# Altium schematic sheet Schematic.SchDoc
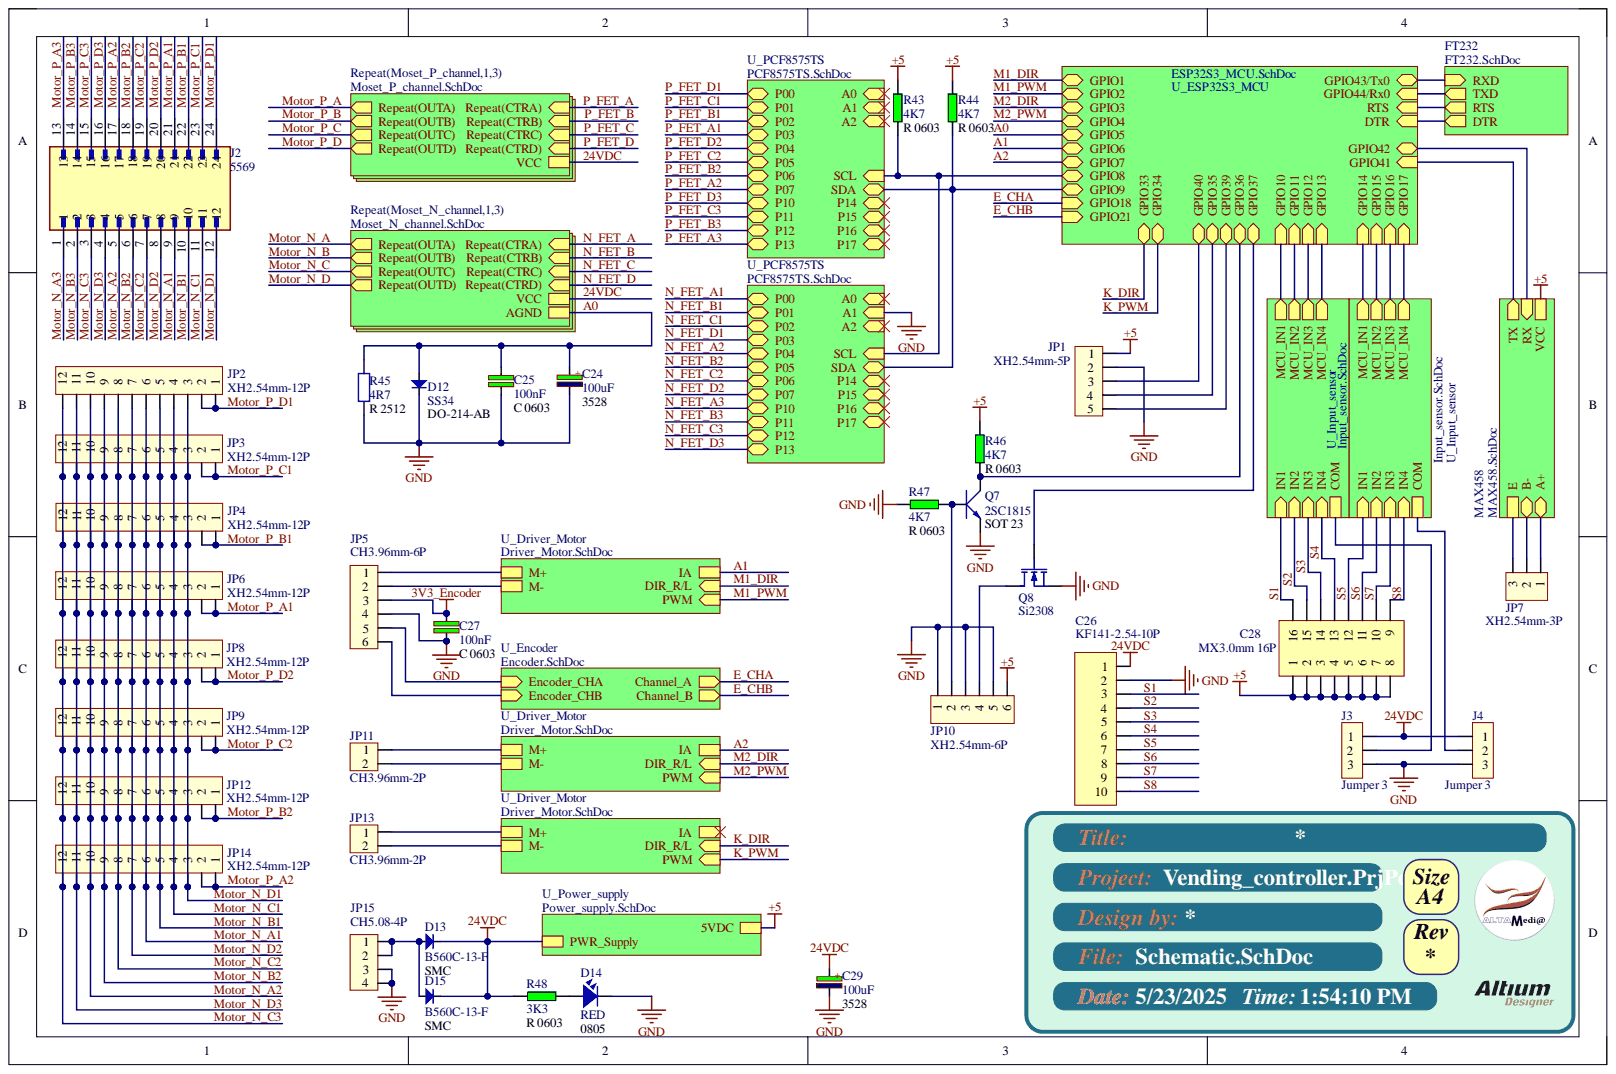
[diagram p0: Schematic.SchDoc]

## Parts
C24  100uF  Size: 3528  [SMD 3528]
C25  100nF  Size: C 0603  [C-0603]
C26  KF141-2.54-10P  [KF141-2.54-10-R]
C27  100nF  Size: C 0603  [C-0603]
C28  MX3.0mm 16P  [MX3.0mm_16P]
C29  100uF  Size: 3528  [CA-ALU-10GR]
D12  SS34  Size: DO-214-AB  [DIO-SMD-106]
D13  B560C-13-F  [DIO-SMD-B]
D14  RED  Size: 0805  [LED-0805-Blue]
D15  B560C-13-F  Size: SMC  [DIO-SMD-B]
J2  5569  [MOLEX_874272442]
J3  Jumper 3  value J3  [JP3]
J4  Jumper 3  [JP3]
JP1  XH2.54mm-5P  [CON_5P]
JP2  XH2.54mm-12P  [CON12]
JP3  XH2.54mm-12P  [CON12]
JP4  XH2.54mm-12P  [CON12]
JP5  CH3.96mm-6P  [CH3.96MM_6P]
JP6  XH2.54mm-12P  [CON12]
JP7  XH2.54mm-3P  [CON3]
JP8  XH2.54mm-12P  [CON12]
JP9  XH2.54mm-12P  [CON12]
JP10  XH2.54mm-6P  [CON_6P]
JP11  CH3.96mm-2P  [CH3.96MM_2P]
JP12  XH2.54mm-12P  [CON12]
JP13  CH3.96mm-2P  [CH3.96MM_2P]
JP14  XH2.54mm-12P  [CON12]
JP15  CH5.08-4P  [CH5.08MM_4P]
Q7  2SC1815  [SOT23B]
Q8  Si2308  [SOT23]
R43  4K7  [R-0603]
R44  4K7  Size: R 0603  [R-0603]
R45  4R7  Size: R 2512  [RES-SMD-2512]
R46  4K7  Size: R 0603  [R-0603]
R47  4K7  [R-0603]
R48  3K3  Size: R 0603  [R-0603]

## Nets
3V3_Encoder: C27.1 JP5.3
+5: C28.1 C28.2 C28.3 C28.4 C28.5 C28.6 C28.7 C28.8 JP1.1 JP10.6 R43.2 R44.2 R46.2
GND: C24.2 C25.2 C26.2 C27.2 C29.2 D12.2 D14.2 J3.3 J4.3 JP1.2 JP5.4 JP10.1 JP10.3 JP10.5 JP15.3 JP15.4 Q7.1 Q8.2 R45.2 R47.1
24VDC: C26.1 C29.1 D13.2 D15.2 J3.1 J4.1 R48.1
A0: C24.1 C25.1 D12.1 R45.1
Motor_N_A1: J2.9 JP2.6 JP3.6 JP4.6 JP6.6 JP8.6 JP9.6 JP12.6 JP14.6
Motor_N_A2: J2.5 JP2.10 JP3.10 JP4.10 JP6.10 JP8.10 JP9.10 JP12.10 JP14.10
Motor_N_A3: J2.1
Motor_N_B1: J2.10 JP2.5 JP3.5 JP4.5 JP6.5 JP8.5 JP9.5 JP12.5 JP14.5
Motor_N_B2: J2.6 JP2.9 JP3.9 JP4.9 JP6.9 JP8.9 JP9.9 JP12.9 JP14.9
Motor_N_B3: J2.2
Motor_N_C1: J2.11 JP2.4 JP3.4 JP4.4 JP6.4 JP8.4 JP9.4 JP12.4 JP14.4
Motor_N_C2: J2.7 JP2.8 JP3.8 JP4.8 JP6.8 JP8.8 JP9.8 JP12.8 JP14.8
Motor_N_C3: J2.3 JP2.12 JP3.12 JP4.12 JP6.12 JP8.12 JP9.12 JP12.12 JP14.12
Motor_N_D1: J2.12 JP2.3 JP3.3 JP4.3 JP6.3 JP8.3 JP9.3 JP12.3 JP14.3
Motor_N_D2: J2.8 JP2.7 JP3.7 JP4.7 JP6.7 JP8.7 JP9.7 JP12.7 JP14.7
Motor_N_D3: J2.4 JP2.11 JP3.11 JP4.11 JP6.11 JP8.11 JP9.11 JP12.11 JP14.11
Motor_P_A1: J2.21 JP6.1 JP6.2
Motor_P_A2: J2.17 JP14.1 JP14.2
Motor_P_A3: J2.13
Motor_P_B1: J2.22 JP4.1 JP4.2
Motor_P_B2: J2.18 JP12.1 JP12.2
Motor_P_B3: J2.14
Motor_P_C1: J2.23 JP3.1 JP3.2
Motor_P_C2: J2.19 JP9.1 JP9.2
Motor_P_C3: J2.15
Motor_P_D1: J2.24 JP2.1 JP2.2
Motor_P_D2: J2.20 JP8.1 JP8.2
Motor_P_D3: J2.16
S1: C26.3 C28.16
S2: C26.4 C28.15
S3: C26.5 C28.14
S4: C26.6 C28.13
S5: C26.7 C28.12
S6: C26.8 C28.11
S7: C26.9 C28.10
S8: C26.10 C28.9
NetD13_1: D13.1 D15.1 JP15.1 JP15.2
NetD14_1: D14.1 R48.2
NetJ3_2: J3.2
NetJ4_2: J4.2
NetJP1_3: JP1.3
NetJP1_4: JP1.4
NetJP1_5: JP1.5
NetJP5_1: JP5.1
NetJP5_2: JP5.2
NetJP5_5: JP5.5
NetJP5_6: JP5.6
NetJP7_1: JP7.1
NetJP7_2: JP7.2
NetJP7_3: JP7.3
NetJP10_2: JP10.2 Q7.3 R47.2
NetJP10_4: JP10.4 Q8.3
NetJP11_1: JP11.1
NetJP11_2: JP11.2
NetJP13_1: JP13.1
NetJP13_2: JP13.2
NetQ7_2: Q7.2 R46.1
NetQ8_1: Q8.1
NetR43_1: R43.1
NetR44_1: R44.1
A1:
A2:
DTR:
E_CHA:
E_CHB:
GPIO10:
GPIO11:
GPIO12:
GPIO13:
GPIO14:
GPIO15:
GPIO16:
GPIO17:
GPIO41:
GPIO42:
GPIO43/Tx0:
GPIO44/Rx0:
IA:
K_DIR:
K_PWM:
M1_DIR:
M1_PWM:
M2_DIR:
M2_PWM:
N_FET_A1:
N_FET_A2:
N_FET_A3:
N_FET_B1:
N_FET_B2:
N_FET_B3:
N_FET_C1:
N_FET_C2:
N_FET_C3:
N_FET_D1:
N_FET_D2:
N_FET_D3:
P14:
P15:
P16:
P17:
P_FET_A1:
P_FET_A2:
P_FET_A3:
P_FET_B1:
P_FET_B2:
P_FET_B3:
P_FET_C1:
P_FET_C2:
P_FET_C3:
P_FET_D1:
P_FET_D2:
P_FET_D3:
RTS:

## Library data of the parts
C24 C29 = CP0: Cap P  0,1uF < 22uF , 16 - 50V; Description 2: 0,1uF => 33uF/50V - 10uF => 22uF/63V; Description 3: 0,47uF => 10uF/100V; Value: 100uF/16V; Component Kind: Standard; Library Name: My Library.SchLib
C25 C27 = CN5: 0,1uF/300V; Component Kind: Standard; Library Name: My Library.SchLib; Value: 100nF
C26 = JP10: WR-PHD 2.54 mm Angled Socket Header; Manufacturer: W¨¹rth Elektronik eiSos GmbH; partNo: 613010143121; ComponentLink1 Description: Manufacturer; ComponentLink2 Description: Datasheet; NoPins: 10; Component Kind: Standard; ComponentLink1URL: http://www.we-online.com; ComponentLink2URL: http://katalog.we-online.de/em/datasheet/6130xx143121.pdf; Created Date: 29 March 2013; Library Name: My Library.SchLib; Created by: Karrer Zheng
C28 = JP8x2: WR-PHD 2.54 mm Dual Socket Header; Manufacturer: W¨¹rth Elektronik eiSos GmbH; partNo: 61301621821; ComponentLink1 Description: Manufacturer; ComponentLink2 Description: Datasheet; NoPins: 16; Component Kind: Standard; ComponentLink1URL: http://www.we-online.com; ComponentLink2URL: http://katalog.we-online.de/em/datasheet/6130xx21821.pdf; Created Date: 29 March 2013; Library Name: My Library.SchLib; Created by: Karrer Zheng
D12 D13 D15 = Diode SMD: Default Diode; Component Kind: Standard; Library Name: My Library.SchLib; Published: 8-Jun-2000; Revision: July-2002: Re-released for DXP Platform.; Publisher: Altium Limited
  D12: Value: SS34
  D13: Size: SMC; Value: B560C-13-F
  D15: Value: B560C-13-F
D14 = LED: LED Single colour Green and Red; FNC: Tx; Component Kind: Standard; Library Name: My Library.SchLib; Value: RED
J2 = 874272442: Availability: Unavailable; Component Kind: Standard; Library Name: My Library.SchLib; MP: 874272442; Package: None; Price: None
J3 = JP3: Component Kind: Standard; Description: Jumper 3; Library Name: My Library.SchLib
J4 = JP3: Value: J3; Component Kind: Standard; Description: Jumper 3; Library Name: My Library.SchLib
JP1 = Con5: Connector 5; Component Kind: Standard; Library Name: My Library.SchLib; Value: Connector 5
JP2-JP4 JP6 JP8 JP9 JP12 JP14 = Con12: Connector 12; Component Kind: Standard; Library Name: My Library.SchLib; Value: Connector 12
JP5 JP10 = Con6: Connector 6; Component Kind: Standard; Library Name: My Library.SchLib; Value: Connector 6
JP7 = Con3: Connector 3; Component Kind: Standard; Library Name: My Library.SchLib; Value: Connector 3
JP11 JP13 = Con2: Connector 2; Component Kind: Standard; Library Name: My Library.SchLib; Value: Connector 2
JP15 = Con4: Connector 4; Component Kind: Standard; Library Name: My Library.SchLib; Value: Connector 4
Q7 = C1815: NPN 600mW, ECB; Component Kind: Standard; Size: SOT 23; Value: 2SC1815; Library Name: syhaunguyen.SchLib
Q8 = Si2302DS: N-Channel MOSFET; Component Kind: Standard; LatestRevisionDate: 17-Jul-2002; LatestRevisionNote: Re-released for DXP Platform.; Library Name: My Library.SchLib; PackageDescription: TO, Flat Index; 3 In-Line, Axial Leads; Body Dia. 4.6mm; Lead Dia. 0.5 mm (max); PackageReference: E3; PackageVersion: 1995/1996; Published: 8-Jun-2000; Publisher: Altium Limited
R43 R44 R46-R48 = RES: SAISO: 5%; Size2: R 0805; Component Kind: Standard; Library Name: Schlib.SchLib
  R43 R47: Size: R 0603; Value: 4K7
  R44 R46: Value: 4K7
  R48: Value: 3K3
R45 = R0805: Resistor SMD 0805; Component Kind: Standard; LatestRevisionDate: 17-Jul-2002; LatestRevisionNote: Re-released for DXP Platform.; Library Name: My Library.SchLib; PackageReference: AXIAL-0.4; Published: 8-Jun-2000; Signal Integrity: Res; Simulation: RESISTOR; Value: 4R7
